# Altium schematic sheet Top sheet.SchDoc
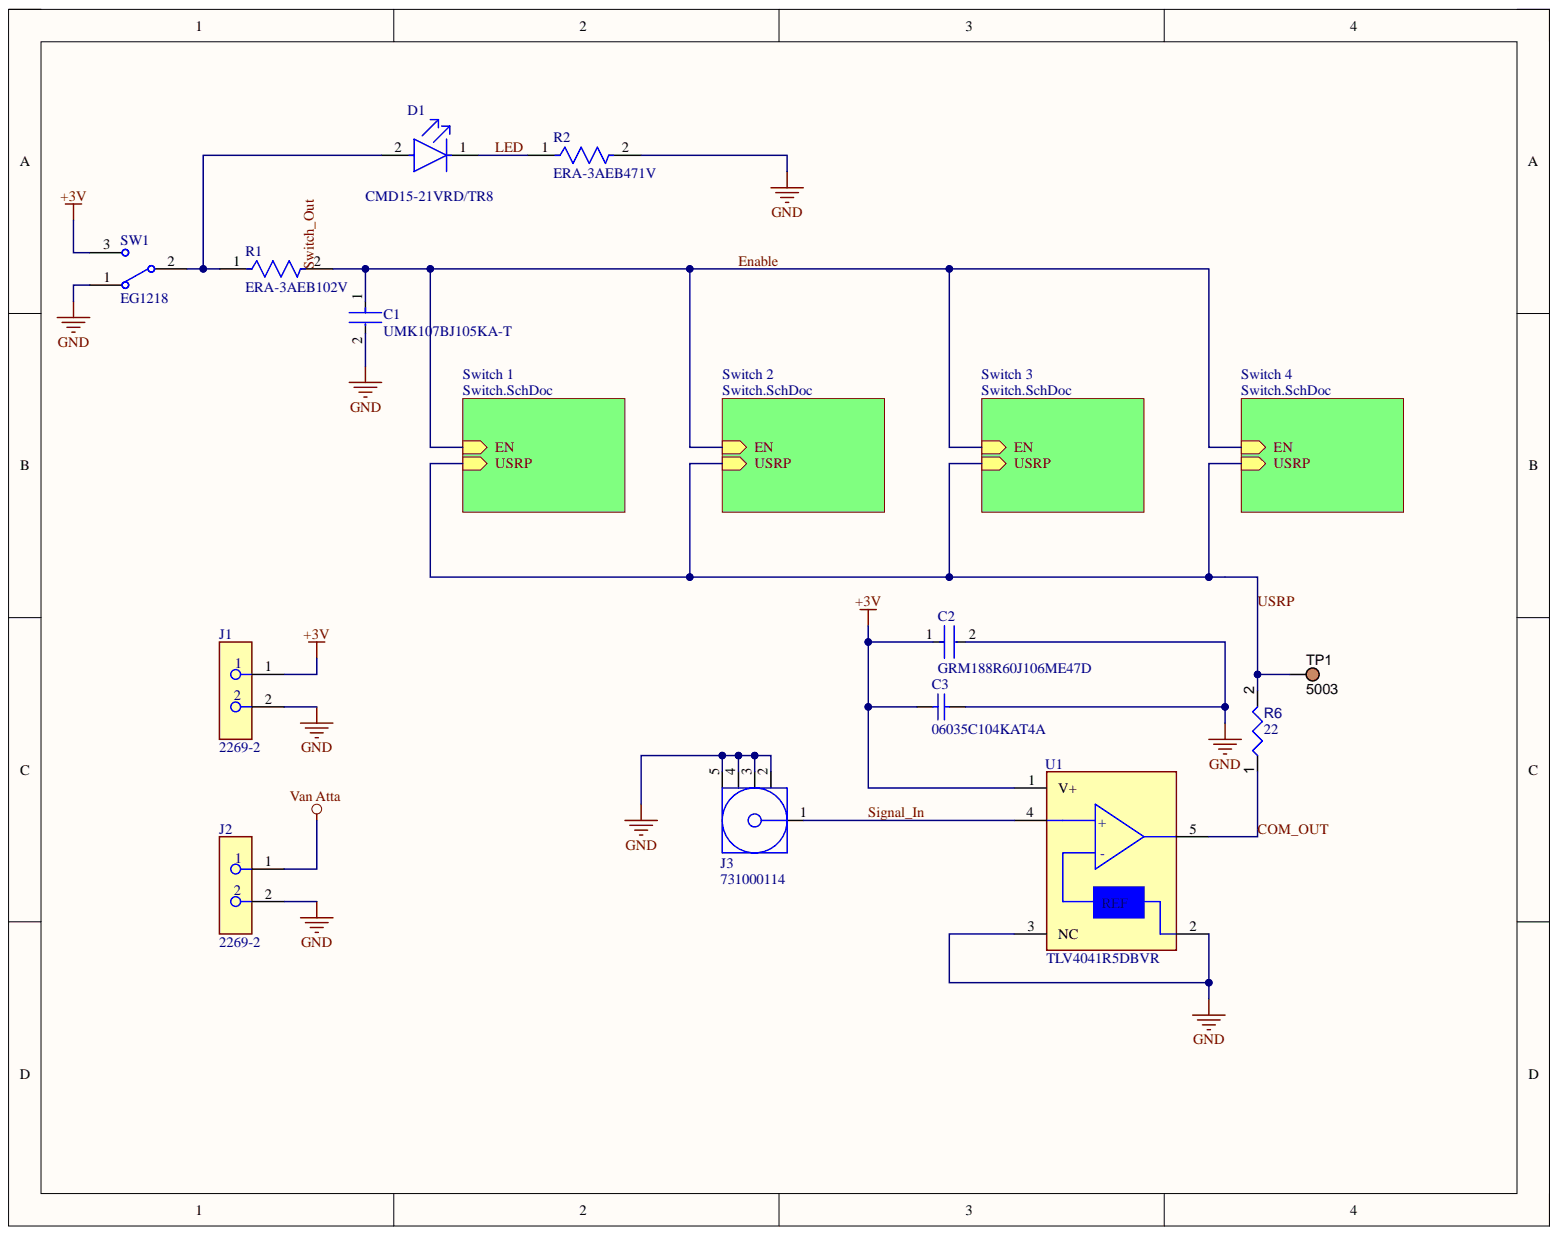
[diagram p0: Top sheet.SchDoc]

## Parts
C1  UMK107BJ105KA-T  [FP-0603-L_1_6_0_1-W_0_8_0-IPC_A]
C2  GRM188R60J106ME47D  [FP-GRM188-0_1-e0_2_0_55-IPC_A]
C3  06035C104KAT4A  [CAPC1608X90X35LL15T15]
D1  CMD15-21VRD/TR8  [FP-CMD15-21-MFG]
J1  2269-2  [FP-2269-2-MFG]
J2  2269-2  [FP-2269-2-MFG]
J3  731000114  [MOLX-731000114_V]
R1  ERA-3AEB102V  [FP-ERA3A-IPC_C]
R2  ERA-3AEB471V  [FP-ERA3A-IPC_A]
R6  value 22  [RESC1609X50X30ML08T20]  (hidden comment: Resistor 22R +/-1% 0603 100 mW)
SW1  EG1218  [ESWI-EG1218_V]
TP1  5003  [KSTN-5003]
U1  TLV4041R5DBVR  [FP-DBV0005A-IPC_C]

## Nets
+3V: C2.1 C3.2 J1.1 SW1.3 U1.1(V+)
GND: C1.2 C2.2 C3.1 J1.2 J2.2 J3.2 J3.3 J3.4 J3.5 R2.2 SW1.1 U1.2 U1.3(NC)
Van Atta: J2.1
COM_OUT: R6.1 U1.5
Enable: C1.1 R1.2
LED: D1.1 R2.1
Signal_In: J3.1 U1.4
USRP: R6.2 TP1.1
NetD1_2: D1.2 R1.1 SW1.2
Switch_Out:

## Library data of the parts
C1 = CMP-2000-05447-3: None; Capacitance: 1uF; Dielectric: X5R; Tolerance: 10%; Voltage Rating: 50V; Case Code (Imperial): 0603; Case Code (Metric): 1608; Case/Package: 0603; Component Kind: Standard; Construction: Flat; Contact Plating: Nickel; Depth: 0.8mm; Halogen Free: Halogen Free; Height: 0.8mm; Length: 1.6mm; Library Name: Altium Content Vault; Max Operating Temperature: 85°C; Min Operating Temperature: -55°C; Mount: Surface Mount; Number of Pins: 2; Package Quantity: 1; Packaging: Tape and Reel; Radiation Hardening: No; RoHS Compliant: Yes; Series: M; Simulation: UMK107BJ105_A-T; Termination: SMD/SMT; Thickness: 0.035inch; Voltage Rating (DC): 50V; Width: 0.031inch
C2 = CMP-2006-02792-2: Chip Multilayer Ceramic Capacitors for General Purpose, 0603, 10uF, X5R, 15%, 20%, 6.3V; Capacitance: 10uF; Case Code (Imperial): 0603; Case Code (Metric): 1608; Case/Package: 0603; Component Kind: Standard; Depth: 0.8mm; Dielectric: X5R; Height: 0.8mm; Lead Free: Lead Free; Length: 1.6mm; Library Name: Altium Content Vault; Material: Ceramic; Max Operating Temperature: 85°C; Min Operating Temperature: -55°C; Mount: Surface Mount; Package Quantity: 4000; Packaging: Tape and Reel; Radiation Hardening: No; REACH SVHC: No SVHC; Termination: SMD/SMT; Thickness: 0.035inch; Tolerance: 20%; Voltage: 6.3V; Voltage Rating: 6.3V; Voltage Rating (DC): 6.3V; Width: 0.031inch
C3 = CMP-2006-04390-1: Manufacturer: AVX Interconnect / Elco; Component Kind: Standard; Library Name: Altium Content Vault; Part Number: 06035C104KAT4A
D1 = CMP-53976-000002-1: LED RED DIFFUSED 1206 SMD; Manufacturer: VCC; Forward Current: 20mA; Forward Voltage: 2V; Led Orientation: Top View; Luminous Intensity: 4mcd; Peak Emmision Wavelength: 640nm; Power Dissipation: 105mW; Reverse Voltage: 5V; Automotive: No; Category: Diode; Colour: Red; Component Kind: Standard; ComponentLink2Description: Datasheet; ComponentLink2URL: https://www.vcclite.com/wp-content/uploads/wpallimport/files/files/CMD1521SeriesRev1.pdf; Device Class L1: Optoelectronics; Device Class L2: LEDs; Device Class L3: unset; Digikey Description: LED RED DIFFUSED 1206 SMD; Height: 1.3mm; Lead Free: Yes; Library Name: Altium Content Vault; Manufacturer Part Number: CMD15-21VRD/TR8; Mouser Description: Standard LEDs - SMD RED DIFFUSED; Octopart: https://octopart.com/cmd15-21vrd%2Ftr8-vcc-26668835; Package: CMD15-21; RoHS: TRUE; Temperature: -40°C to +85°C; Temperature Range High: +85°C; Temperature Range Low: -40°C
J1 J2 = CMP-73906-000010-1: CONN DBL BANANA JACK TURRET; Body Material: PC; Current Rating: 15A; Gender: Female; Insulation: Mating End Insulated; Number of Contacts: 2; Voltage Rating: 2.5kV; Voltage Rating (DC): 70V; Color: Red; Component Kind: Standard; Contact Material: Brass; Contact Plating: Tin; Depth: 16mm; Height: 0.375inch; Housing Color: Red; Housing Material: Polycarbonate; Insulation Material: Polycarbonate; Lead Free: Lead Free; Length: 24inch; Library Name: Altium Content Vault; Max Current Rating: 15A; Mount: Panel; Number of Ports: 2; Orientation: Straight; Package Quantity: 5; Packaging: Bulk; REACH SVHC: No SVHC; RoHS Compliant: Yes; Termination: Solder; Weight: 0.033lb; Width: 4inch
J3 = CMP-2000-05487-1: SMA Right-Angle PCB Jack Receptacle, Brass Body, Gold Plated, 50 Ohm, 5-Pin THD, RoHS, Tray; Manufacturer: Molex; Component Kind: Standard; Datasheet URL: http://www.molex.com/webdocs/datasheets/pdf/en-us/0731000114_RF_COAX_CONNECTORS.pdf; Datasheet Version: 09/2016; Library Name: Altium Content Vault; Manufacturer URL: http://www.molex.com/; Mounting Technology: ThroughHole; Package Description: 5-Pin Through Hole Device, Body 14.8 x 6.35 mm, Pitch 2.54 mm; Package Reference: 731000114; Package URL: http://www.molex.com/pdm_docs/sd/731000114_sd.pdf
R1 = CMP-2000-00873-2: RES SMD 1K OHM 0.1% 1/10W 0603; Max Power Dissipation: 100mW; Resistance: 1kR; Temperature Coefficient: 25ppm/°C; Voltage Rating: 75V; Case Code (Imperial): 0603; Case Code (Metric): 1608; Case/Package: 0603; Component Kind: Standard; Construction: Rectangular; Contact Plating: Tin; Depth: 0.8mm; Height: 0.45mm; Length: 1.6mm; Library Name: Altium Content Vault; Max Operating Temperature: 155°C; Military Standard: Not; Min Operating Temperature: -55°C; Mount: Surface Mount; Number of Pins: 2; Number of Terminations: 2; Package Quantity: 5000; Packaging: Tape and Reel; Power Rating: 100mW; Radiation Hardening: No; REACH SVHC: No SVHC; Resistance Tolerance: 0.1%; Resistor Type: Thin Film; RoHS Compliant: Yes; Series: ERA-3A; Size Code: 0603; Technology: METAL FILM; Termination: SMD/SMT; Tolerance: 0.1%; Width: 0.8mm
R2 = CMP-2000-00071-2: RES SMD 470 OHM 0.1% 1/10W 0603; Max Power Dissipation: 100mW; Resistance: 470R; Temperature Coefficient: 25ppm/°C; Voltage Rating: 75V; Case Code (Imperial): 0603; Case Code (Metric): 1608; Case/Package: 0603; Component Kind: Standard; Construction: Rectangular; Contact Plating: Tin; Depth: 0.8mm; Features: Automotive AEC-Q200; Height: 0.45mm; Lead Free: Lead Free; Length: 1.6mm; Library Name: Altium Content Vault; Max Operating Temperature: 155°C; Military Standard: Not; Min Operating Temperature: -55°C; Mount: Surface Mount; Number of Pins: 2; Number of Terminations: 2; Package Quantity: 1; Packaging: Tape and Reel; Power Rating: 100mW; Radiation Hardening: No; REACH SVHC: No SVHC; Resistance Tolerance: 0.1%; Resistor Type: Thin Film; RoHS Compliant: Yes; Series: ERA-3A; Size Code: 0603; Technology: THIN FILM; Termination: SMD/SMT; Tolerance: 0.1%; Voltage Rating (DC): 75V; Width: 0.8mm
R6 = CMP-009-00027-5: Chip Resistor, 22 Ohm, +/- 1%, 100 mW, -55 to 155 degC, 0603 (1608 Metric); Power: 100mW; Voltage Rating: 75V; Case/Package: 0603; Component Kind: Standard; Max Operating Temperature: 155°C; Min Operating Temperature: -55°C; Mounting Technology: SM; Pins: 2; RoHS Compliant: True; Tolerance: 1%; Library Name: Nick
SW1 = CMP-011-00006-2: Slide Switch, SPDT, 500 V, -20 to 70 degC, 3-Pin THD, RoHS, Bulk; Manufacturer: E-Switch; Contact Current Rating: 200mA; Operating Force: 250gf; Voltage Rating (AC): 30V; Case/Package: EG1218; Component Kind: Standard; Contact Finish: Silver; Contact Material: Copper; Contact Plating: Silver; Current Rating: 200mA; Datasheet URL: https://www.e-switch.com/system/asset/product_line/data_sheet/119/EG.pdf; Datasheet Version: Oct-15; Manufacturer URL: http://www.e-switch.ch/en/; Max Operating Temperature: 70°C; Min Operating Temperature: -20°C; Mounting Technology: TH; Package Description: 3-Pin Through Hole Device, Body 11.6 x 4 mm, Pitch 2.5 mm; Package Quantity: 1000; Package Reference: EG1218; Packaging: Bulk; Pins: 3; RoHS Compliant: True; Throw Configuration: SPDT; Voltage Rating (DC): 30V; Library Name: Nick
TP1 = CMP-002-00037-2: Test Point, Orange, Height 4.6 mm, Tail Length 3 mm, 1-Pin THD, RoHS; Manufacturer: Keystone; Case/Package: 5003; Colour: Orange; Component Kind: Standard; Connector Type: TestPoint; Contact Material: Bronze; Contact Plating: Tin; Datasheet URL: http://keyelco.com/userAssets/file/M65p56.pdf; Datasheet Version: Jun-15; Diameter: 2.54mm; Manufacturer URL: http://www.keyelco.com/; Mounting Technology: TH; Package Description: 1-Pin Through Hole Device, Dia 2.54 mm; Package Quantity: 10; Package Reference: 5003; Packaging: Bulk; Pins: 1; RoHS Compliant: True; Library Name: Nick
U1 = CMP-04896-000141-1: LOW-POWER COMPARATOR WITH REFERE; Component Kind: Standard; Library Name: Altium Content Vault
